# Altium schematic sheet MCU.SchDoc(MCU)
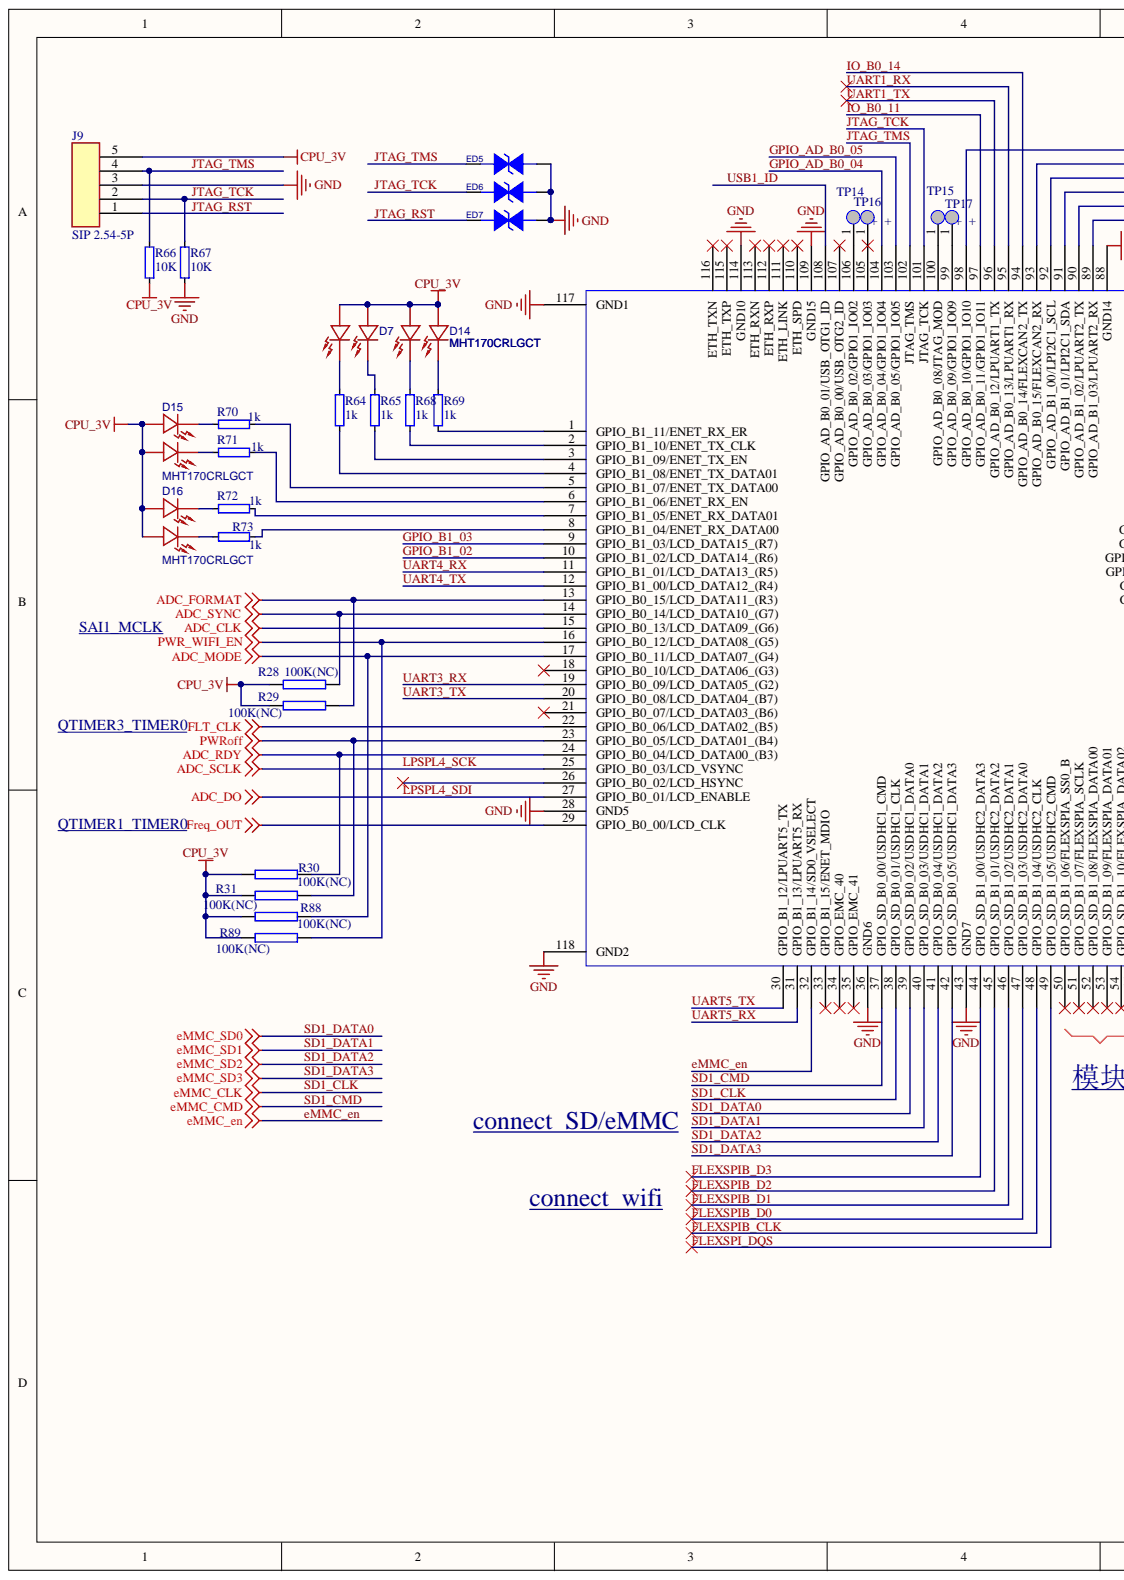
[diagram p0: MCU.SchDoc, left]
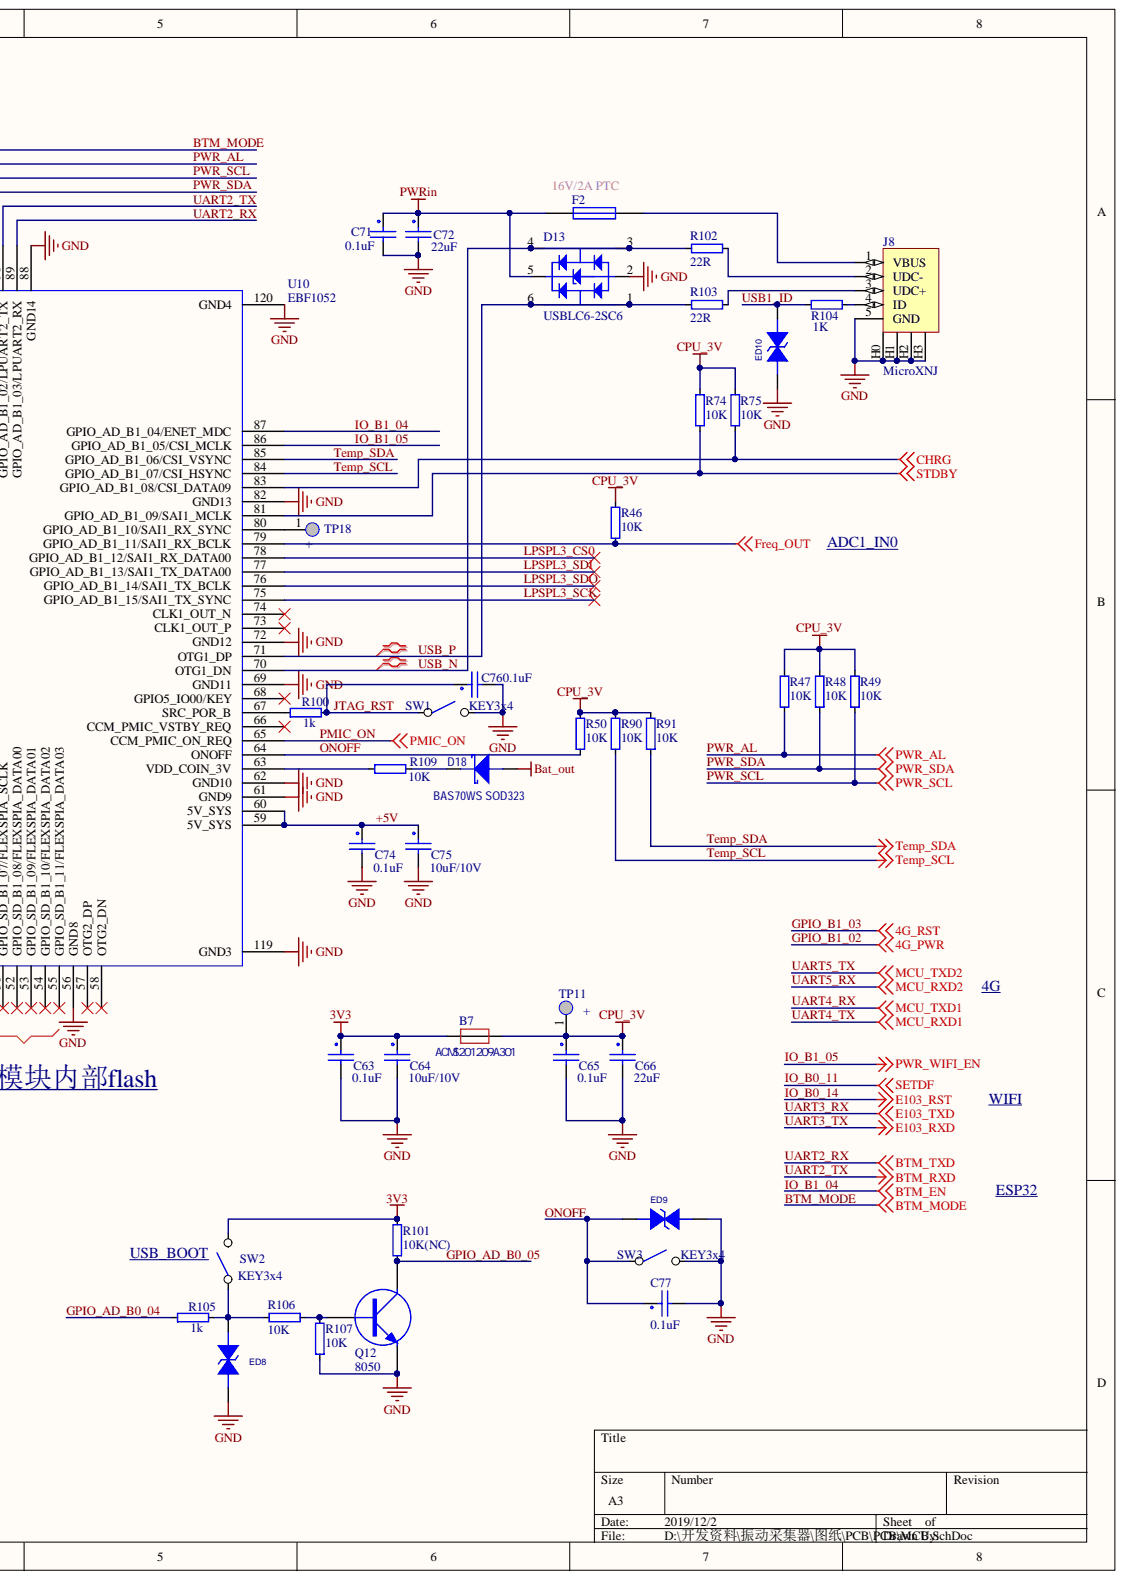
[diagram p1: MCU.SchDoc, right]

## Parts
B7  ACMS201209A301  [0805_R]
C63  0.1uF  [0402_C]
C64  10uF/10V  [0603_C]
C65  0.1uF  [0402_C]
C66  22uF  [0603_C]
C71  0.1uF  [0402_C]
C72  22uF  [0603_C]
C74  0.1uF  [0402_C]
C75  10uF/10V  [0603_C]
C76  0.1uF  [0402_C]
C77  0.1uF  [0402_C]
D7  MHT170CRLGCT  [LED2_0805]
D13  USBLC6-2SC6  [SC-70-6(SOT-363)]
D14  MHT170CRLGCT  [LED2_0805]
D15  MHT170CRLGCT  [LED2_0805]
D16  MHT170CRLGCT  [LED2_0805]
D18  BAS70WS SOD323  [SOD-323]
ED5  ESD3Z5.0C  [SOD323]  (value not drawn)
ED6  ESD3Z5.0C  [SOD323]  (value not drawn)
ED7  ESD3Z5.0C  [SOD323]  (value not drawn)
ED8  ESD3Z5.0C  [SOD323]  (value not drawn)
ED9  ESD3Z5.0C  [SOD323]  (value not drawn)
ED10  ESD3Z5.0C  [SOD323]  (value not drawn)
F2  16V/2A PTC  [1812]
J8  MicroXNJ  [USB-005H]
J9  SIP 2.54-5P  [CON5]
Q12  8050  [SOT-23(SOT-23-3)]
R28  100K(NC)  [0402_R]
R29  100K(NC)  [0402_R]
R30  100K(NC)  [0402_R]
R31  100K(NC)  [0402_R]
R46  10K  [0402]
R47  10K  [0402]
R48  10K  [0402]
R49  10K  [0402]
R50  10K  [0402]
R64  1k  [0402]
R65  1k  [0402]
R66  10K  [0402]
R67  10K  [0402]
R68  1k  [0402]
R69  1k  [0402]
R70  1k  [0402]
R71  1k  [0402]
R72  1k  [0402]
R73  1k  [0402]
R74  10K  [0402]
R75  10K  [0402]
R88  100K(NC)  [0402_R]
R89  100K(NC)  [0402_R]
R90  10K  [0402]
R91  10K  [0402]
R100  1k  [0402]
R101  10K(NC)  [0402]
R102  22R  [0402]
R103  22R  [0402]
R104  1K  [0402]
R105  1k  [0402]
R106  10K  [0402]
R107  10K  [0402]
R109  10K  [0402]
SW1  KEY3x4  [SMDKEY]
SW2  KEY3x4  [SMDKEY]
SW3  KEY3x4  [SMDKEY]
TP11  +  [TP]
TP14  +  [TP]
TP15  +  [TP]
TP16  +  [TP]
TP17  +  [TP]
TP18  +  [TP]
U10  EBF1052  [RT1052_CORE_P120]

## Nets
3V3: B7.1 C63.1 C64.1 R101.1 SW2.2
ADC_CLK: U10.15(GPIO_B0_13/LCD_DATA09_(G6))
ADC_FORMAT: R29.2 U10.13(GPIO_B0_15/LCD_DATA11_(R3))
ADC_MODE: R88.2 U10.17(GPIO_B0_11/LCD_DATA07_(G4))
ADC_RDY: R30.2 U10.24(GPIO_B0_04/LCD_DATA00_(B3))
ADC_SYNC: R28.2 U10.14(GPIO_B0_14/LCD_DATA10_(G7))
BAT_OUT: D18.A
CHRG: R75.2 U10.83(GPIO_AD_B1_08/CSI_DATA09)
CPU_3V: B7.2 C65.1 C66.1 D7.3 D7.4 D14.3 D14.4 D15.3 D15.4 D16.3 D16.4 J9.5 R28.1 R29.1 R30.1 R31.1 R46.1 R47.1 R48.1 R49.1 R50.1 R66.2 R74.1 R75.1 R88.1 R89.1 R90.1 R91.1 TP11.1
FLT_CLK: U10.22(GPIO_B0_06/LCD_DATA02_(B5))
FREQ_OUT: R46.2 U10.29(GPIO_B0_00/LCD_CLK) U10.79(GPIO_AD_B1_11/SAI1_RX_BCLK)
GND: C63.2 C64.2 C65.2 C66.2 C71.2 C72.2 C74.2 C75.2 C76.2 C77.2 D13.2 ED5.2 ED6.2 ED7.2 ED8.1 ED9.2 ED10.1 J8.5(GND) J8.H0 J8.H1 J8.H2 J8.H3 J9.3 Q12.2 R67.2 R107.2 SW1.2 SW3.2 U10.28(GND5) U10.36(GND6) U10.43(GND7) U10.56(GND8) U10.61(GND9) U10.62(GND10) U10.69(GND11) U10.72(GND12) U10.82(GND13) U10.88(GND14) U10.109(GND15) U10.114(GND10) U10.117(GND1) U10.118(GND2) U10.119(GND3) U10.120(GND4)
PWRIN: C71.1 C72.1 D13.5 F2.2
PWROFF: R31.2 U10.23(GPIO_B0_05/LCD_DATA01_(B4))
STDBY: R74.2 U10.81(GPIO_AD_B1_09/SAI1_MCLK)
+5V: C74.1 C75.1 U10.59(5V_SYS) U10.60(5V_SYS)
BTM_MODE: U10.98(GPIO_AD_B0_10/GPIO1_IO10)
EMMC_EN: U10.32(GPIO_B1_14/SD0_VSELECT)
FLEXSPI_DQS: U10.49(GPIO_SD_B1_05/USDHC2_CMD)
FLEXSPIB_CLK: U10.48(GPIO_SD_B1_04/USDHC2_CLK)
FLEXSPIB_D0: U10.47(GPIO_SD_B1_03/USDHC2_DATA0)
FLEXSPIB_D1: U10.46(GPIO_SD_B1_02/USDHC2_DATA1)
FLEXSPIB_D2: U10.45(GPIO_SD_B1_01/USDHC2_DATA2)
FLEXSPIB_D3: U10.44(GPIO_SD_B1_00/USDHC2_DATA3)
GPIO_AD_B0_04: R105.2 U10.104(GPIO_AD_B0_04/GPIO1_IO04)
GPIO_AD_B0_05: Q12.3 R101.2 U10.103(GPIO_AD_B0_05/GPIO1_IO05)
GPIO_B1_02: U10.10(GPIO_B1_02/LCD_DATA14_(R6))
GPIO_B1_03: U10.9(GPIO_B1_03/LCD_DATA15_(R7))
IO_B0_11: U10.97(GPIO_AD_B0_11/GPIO1_IO11)
IO_B0_14: U10.94(GPIO_AD_B0_14/FLEXCAN2_TX)
IO_B1_04: U10.87(GPIO_AD_B1_04/ENET_MDC)
IO_B1_05: R89.2 U10.16(GPIO_B0_12/LCD_DATA08_(G5)) U10.86(GPIO_AD_B1_05/CSI_MCLK)
JTAG_RST: C76.1 ED7.1 J9.1 R100.1 SW1.1
JTAG_TCK: ED6.1 J9.2 R67.1 U10.101(JTAG_TCK)
JTAG_TMS: ED5.1 J9.4 R66.1 U10.102(JTAG_TMS)
LPSPL3_CS0: U10.78(GPIO_AD_B1_12/SAI1_RX_DATA00)
LPSPL3_SCK: U10.75(GPIO_AD_B1_15/SAI1_TX_SYNC)
LPSPL3_SDI: U10.77(GPIO_AD_B1_13/SAI1_TX_DATA00)
LPSPL3_SDO: U10.76(GPIO_AD_B1_14/SAI1_TX_BCLK)
LPSPL4_SCK: U10.25(GPIO_B0_03/LCD_VSYNC)
LPSPL4_SDI: U10.27(GPIO_B0_01/LCD_ENABLE)
ONOFF: C77.1 ED9.1 R50.2 SW3.1 U10.64(ONOFF)
PMIC_ON: U10.65(CCM_PMIC_ON_REQ)
PWR_AL: R47.2 U10.93(GPIO_AD_B0_15/FLEXCAN2_RX)
PWR_SCL: R49.2 U10.92(GPIO_AD_B1_00/LPI2C1_SCL)
PWR_SDA: R48.2 U10.91(GPIO_AD_B1_01/LPI2C1_SDA)
SD1_CLK: U10.38(GPIO_SD_B0_01/USDHC1_CLK)
SD1_CMD: U10.37(GPIO_SD_B0_00/USDHC1_CMD)
SD1_DATA0: U10.39(GPIO_SD_B0_02/USDHC1_DATA0)
SD1_DATA1: U10.40(GPIO_SD_B0_03/USDHC1_DATA1)
SD1_DATA2: U10.41(GPIO_SD_B0_04/USDHC1_DATA2)
SD1_DATA3: U10.42(GPIO_SD_B0_05/USDHC1_DATA3)
TEMP_SCL: R90.2 U10.84(GPIO_AD_B1_07/CSI_HSYNC)
TEMP_SDA: R91.2 U10.85(GPIO_AD_B1_06/CSI_VSYNC)
UART1_RX: U10.95(GPIO_AD_B0_13/LPUART1_RX)
UART1_TX: U10.96(GPIO_AD_B0_12/LPUART1_TX)
UART2_RX: U10.89(GPIO_AD_B1_03/LPUART2_RX)
UART2_TX: U10.90(GPIO_AD_B1_02/LPUART2_TX)
UART3_RX: U10.19(GPIO_B0_09/LCD_DATA05_(G2))
UART3_TX: U10.20(GPIO_B0_08/LCD_DATA04_(B7))
UART4_RX: U10.11(GPIO_B1_01/LCD_DATA13_(R5))
UART4_TX: U10.12(GPIO_B1_00/LCD_DATA12_(R4))
UART5_RX: U10.31(GPIO_B1_13/LPUART5_RX)
UART5_TX: U10.30(GPIO_B1_12/LPUART5_TX)
USB1_ID: ED10.2 R104.1 U10.108(GPIO_AD_B0_01/USB_OTG1_ID)
USB_N: D13.3 D13.4 R102.1 U10.70(OTG1_DN)
USB_P: D13.1 D13.6 R103.1 U10.71(OTG1_DP)
NetD7_1: D7.1 R65.2
NetD7_2: D7.2 R64.2
NetD14_1: D14.1 R69.2
NetD14_2: D14.2 R68.2
NetD15_1: D15.1 R70.2
NetD15_2: D15.2 R71.2
NetD16_1: D16.1 R72.2
NetD16_2: D16.2 R73.2
NetD18_C: D18.C R109.2
NetED8_2: ED8.2 R105.1 R106.1 SW2.1
NetF2_1: F2.1 J8.1(VBUS)
NetJ8_2: J8.2(UDC-) R102.2
NetJ8_3: J8.3(UDC+) R103.2
NetJ8_4: J8.4(ID) R104.2
NetQ12_1: Q12.1 R106.2 R107.1
NetR64_1: R64.1 U10.4(GPIO_B1_08/ENET_TX_DATA01)
NetR65_1: R65.1 U10.3(GPIO_B1_09/ENET_TX_EN)
NetR68_1: R68.1 U10.2(GPIO_B1_10/ENET_TX_CLK)
NetR69_1: R69.1 U10.1(GPIO_B1_11/ENET_RX_ER)
NetR70_1: R70.1 U10.5(GPIO_B1_07/ENET_TX_DATA00)
NetR71_1: R71.1 U10.6(GPIO_B1_06/ENET_RX_EN)
NetR72_1: R72.1 U10.7(GPIO_B1_05/ENET_RX_DATA01)
NetR73_1: R73.1 U10.8(GPIO_B1_04/ENET_RX_DATA00)
NetR100_2: R100.2 U10.67(SRC_POR_B)
NetR109_1: R109.1 U10.63(VDD_COIN_3V)
NetTP14_1: TP14.1 U10.106(GPIO_AD_B0_02/GPIO1_IO02)
NetTP15_1: TP15.1 U10.100(GPIO_AD_B0_08/JTAG_MOD)
NetTP16_1: TP16.1 U10.105(GPIO_AD_B0_03/GPIO1_IO03)
NetTP17_1: TP17.1 U10.99(GPIO_AD_B0_09/GPIO1_IO09)
NetTP18_1: TP18.1 U10.80(GPIO_AD_B1_10/SAI1_RX_SYNC)

Not connected: U10 18(GPIO_B0_10/LCD_DATA06_(G3)) 21(GPIO_B0_07/LCD_DATA03_(B6)) 26(GPIO_B0_02/LCD_HSYNC) 33(GPIO_B1_15/ENET_MDIO) 34(GPIO_EMC_40) 35(GPIO_EMC_41) 50(GPIO_SD_B1_06/FLEXSPIA_SS0_B) 51(GPIO_SD_B1_07/FLEXSPIA_SCLK) 52(GPIO_SD_B1_08/FLEXSPIA_DATA00) 53(GPIO_SD_B1_09/FLEXSPIA_DATA01) 54(GPIO_SD_B1_10/FLEXSPIA_DATA02) 55(GPIO_SD_B1_11/FLEXSPIA_DATA03) 57(OTG2_DP) 58(OTG2_DN) 66(CCM_PMIC_VSTBY_REQ) 68(GPIO5_IO00/KEY) 73(CLK1_OUT_P) 74(CLK1_OUT_N) 107(GPIO_AD_B0_00/USB_OTG2_ID) 110(ETH_SPD) 111(ETH_LINK) 112(ETH_RXP) 113(ETH_RXN) 115(ETH_TXP) 116(ETH_TXN)

## Library data of the parts
B7 = Bead: Component Kind: Standard; Library Name: RP3288BV03_20180126-.SCHLIB
C63 C65 C71 C74 C76 C77 = CAP_100N_25V_0402_10%_X5R: MANUFACTURER: TAIYO YUDEN; PART: TMK105BJ104KV-F; Component Kind: Standard; Description: 0.1uF; FARNELL: 1611916; Library Name: Arbury_C.SchLib
C64 C66 C72 C75 = CAP_100N_25V_0402_10%_X5R: 100nF; MANUFACTURER: TAIYO YUDEN; PART: TMK105BJ104KV-F; Component Kind: Standard; FARNELL: 1611916; Library Name: Arbury_C.SchLib
D7 D14-D16 = MHT170CRLGCT: BOM_Manufacturer: MEIHUA; Contributor: LCSC; BOM_Manufacturer Part: MHT170CRLGCT; BOM_Supplier: LCSC; BOM_Supplier Part: C391863; Component Kind: Standard; spicePre: L
D13 = D_ESD_PRTR5V0U2F_115: Ultra low capacitance double rail-to-rail ESD protection; MANUFACTURER: NXP; Component Kind: Standard; FARNELL: 2459738; PART: PRTR5V0U2F_115; Library Name: Arbury_SCH.SchLib
D18 = DIO-SCH: Diode schottky; Component Kind: Standard; Library Name: Diodes_cn.SCHLIB
ED5-ED10 = DIODE BI-DIR TRIG: ESD; Component Kind: Standard
F2 = FUSE1: Fuse; Component Kind: Standard
J8 = CON_USB_MICRO_AB_MOLEX: USB Micro-AB socket Molex 47589-0001; RS: 702-5488; Component Kind: Standard; Farnell: 156-8023; MANUFACTURER: Molex; PART: 47589-0001; Library Name: Arbury_CON.SchLib
J9 = CON5: Component Kind: Standard; Library Name: 下位机控制板.SCHLIB
Q12 = NPN: NPN Transistor; Component Kind: Standard
R28-R31 R88 R89 = RES2: Component Kind: Standard
R46-R50 R64-R75 R90 R91 R100-R107 R109 = R0402-12k: Resistor, ?%, 0.063W; Artikkelnr Noca: 27049099; Database Table Name: Resistors$; Note: Chip Res 1%; Value: 12k; Component Kind: Standard; LatestRevisionDate: 2009-11-23; Library Name: Nordic Passive DB.DbLib; Part Number: R0402-12k; Pin Count: 2; Published: 2009-05-08; Supplier: Farnell; Supplier part number: 173-8865; Publisher: Nordic Semiconductor
SW1-SW3 = SW_SPST_6MM: SPST SWITCH 6MM; MANUFACTURER: TE CONNECTIVITY / ALCOSWITCH; PART: FSM4JSMA; Component Kind: Standard; FARNELL: 3801305; Library Name: Arbury_SCH.SchLib
TP11 TP14-TP18 = TP: Component Kind: Standard; Library Name: mysch.SchLib
U10 = RT1052_CORE_P120: Component Kind: Standard; Library Name: RT1052_CORE_P120.lib
